# Altium schematic sheet PadDB9_v4.SchDoc
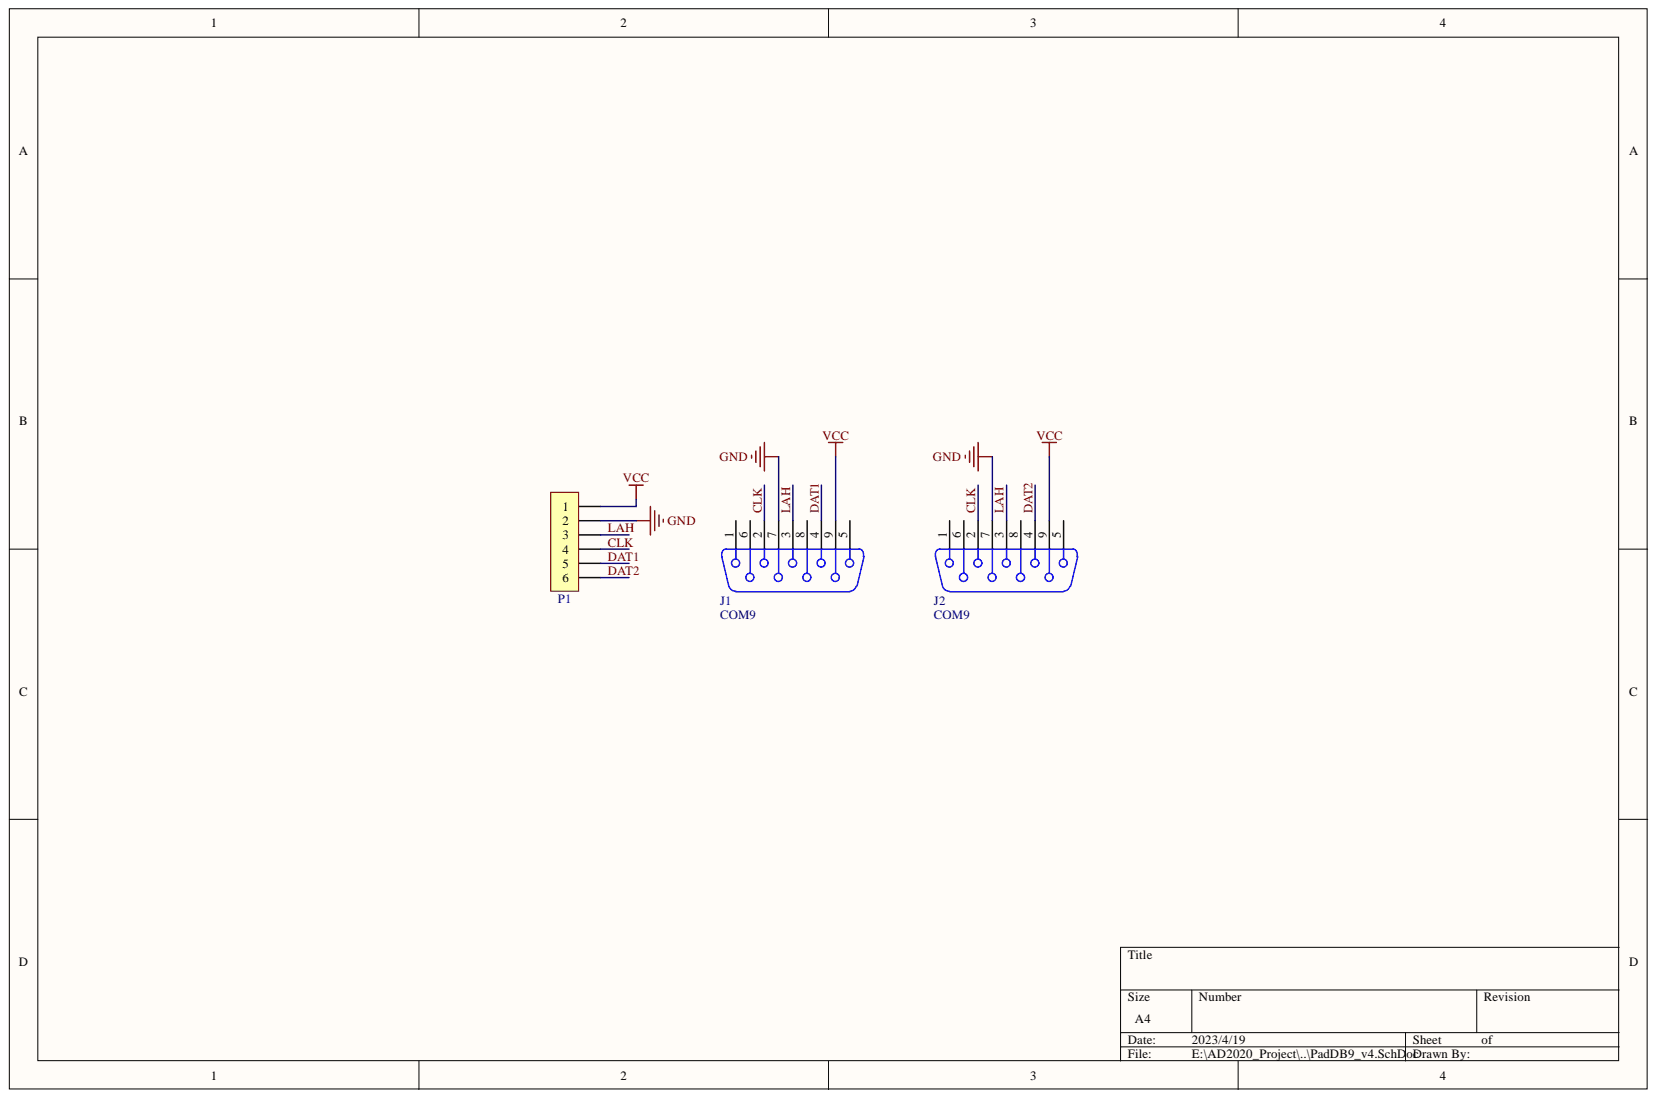
[diagram p0: PadDB9_v4.SchDoc]

## Parts
J1  COM9  [COM9]
J2  COM9  [COM9]
P1  name  [HDR_2.54_1X6_Hole0.8mm]  (value not drawn)

## Nets
GND: J1.7 J2.7 P1.2
VCC: J1.9 J2.9 P1.1
CLK: J1.2 J2.2 P1.4
DAT1: J1.4 P1.5
DAT2: J2.4 P1.6
LAH: J1.3 J2.3 P1.3

Not connected: J1 1 5 6 8; J2 1 5 6 8

## Library data of the parts
J1 J2 = DB9: Gender: Receptacle; PackageDocument: O; Positions: 9; Component Kind: Standard; DatasheetDocument: 1-Sep-1999; Description: COM9; LatestRevisionDate: 17-Jul-2002; LatestRevisionNote: Re-released for DXP Platform.; Library Name: Schlib1.SchLib; Orientation: Right Angle; PackageReference: 788750; Published: 15-Jun-2001; Signal Integrity: Connector; Publisher: Altium Limited
P1 = Header_1x6: Header, 6-Pin; BOM名称: 4P接插件; 版权归属: 源创客; Component Kind: Standard; 学习资源: www.ooocke.com; Library Name: WxdEleDesign.IntLib
